# Altium schematic sheet 01 HK4530 V2.1 main.SchDoc
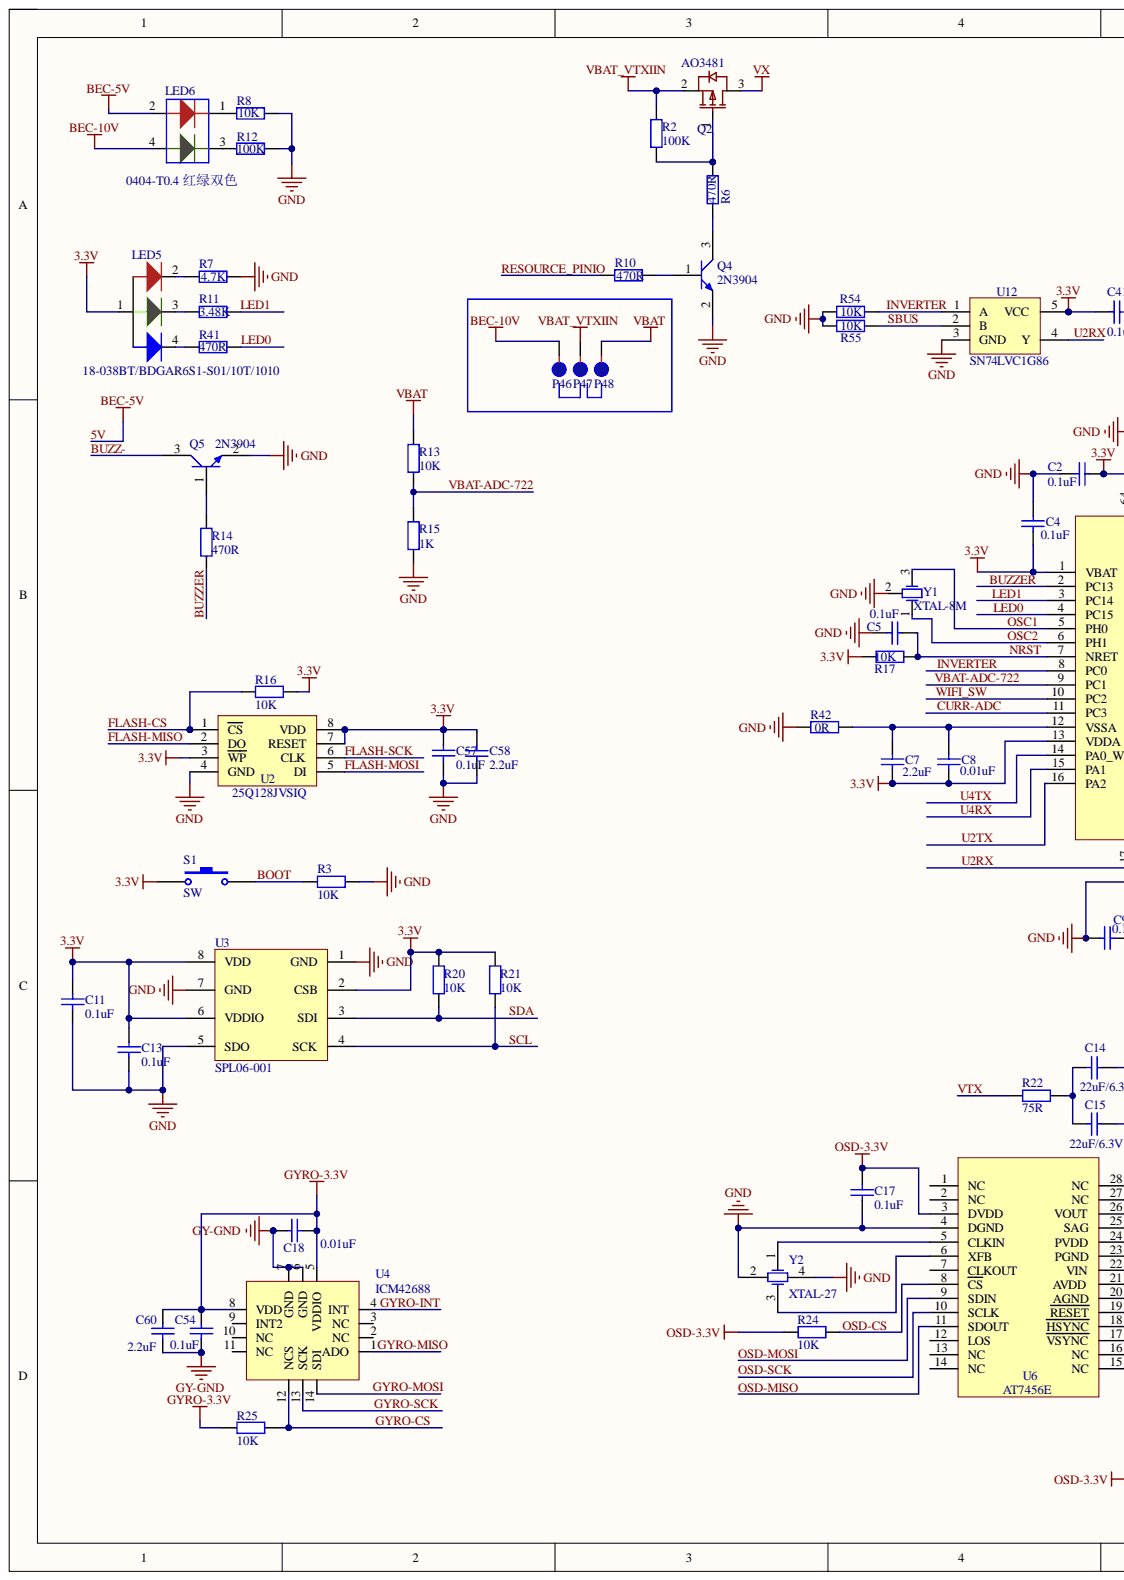
[diagram p0: 01 HK4530 V2.1 main.SchDoc, left]
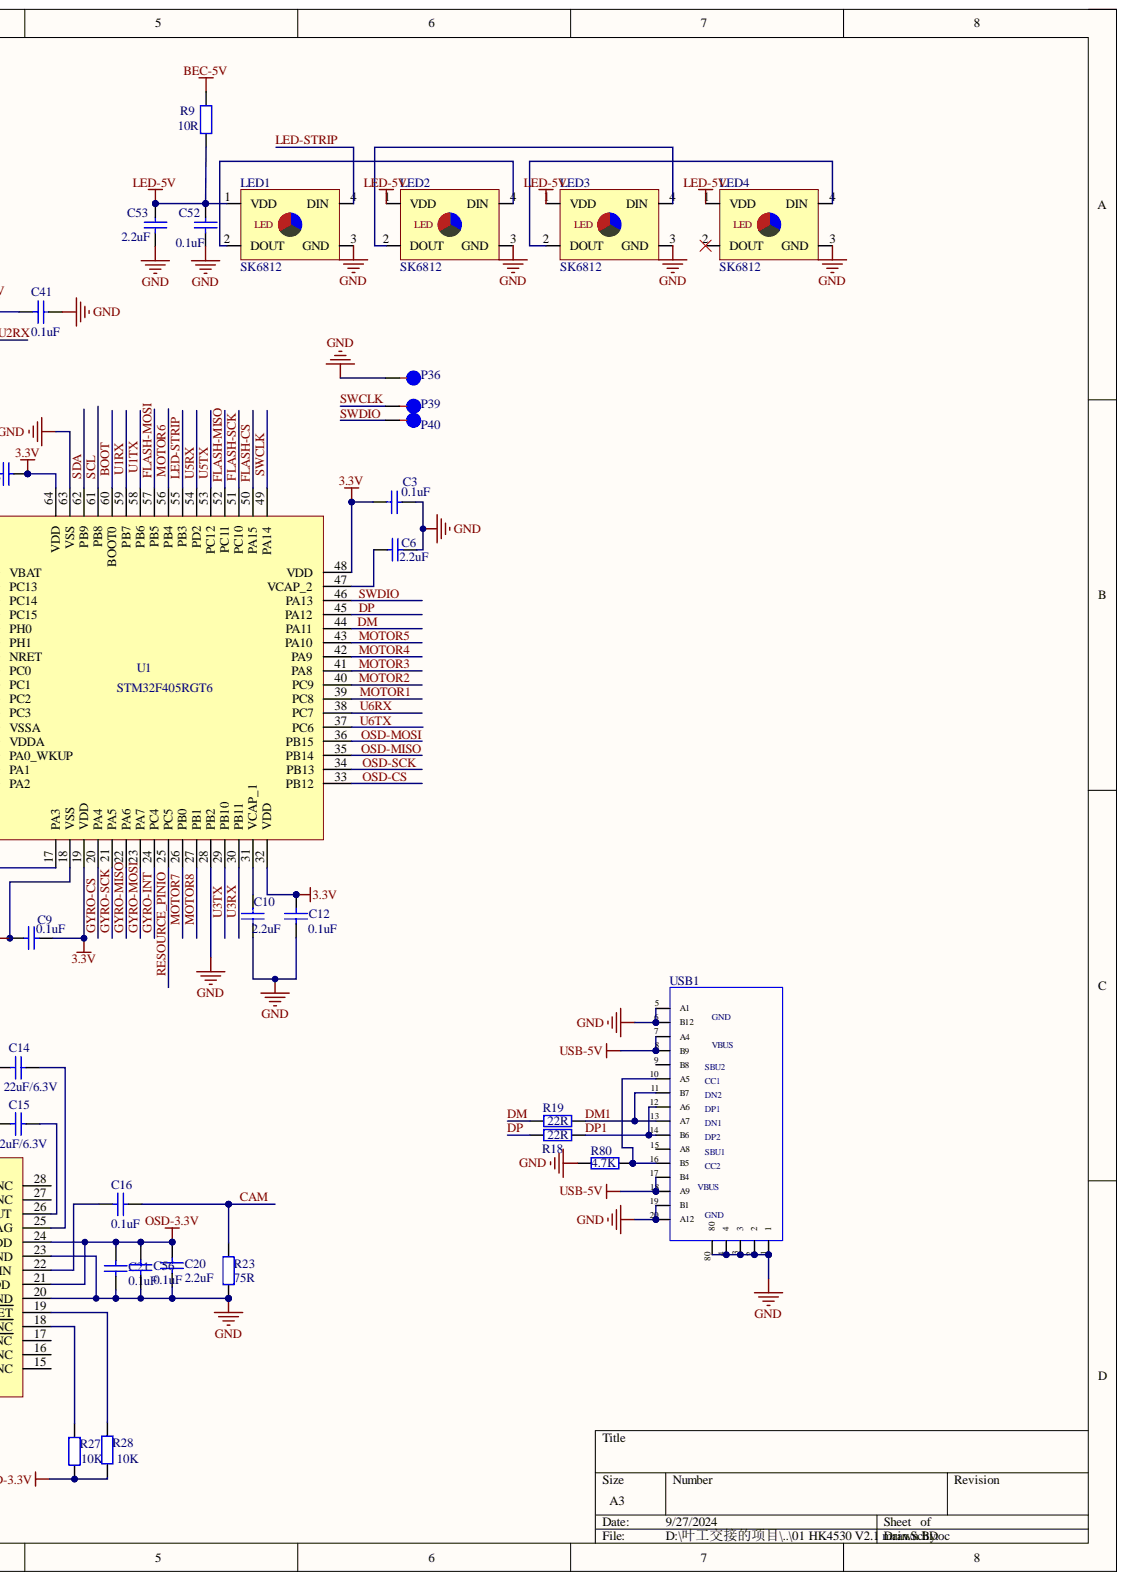
[diagram p1: 01 HK4530 V2.1 main.SchDoc, right]

## Parts
C2  0.1uF  [C0201]
C3  0.1uF  [C0201]
C4  0.1uF  [C0201]
C5  0.1uF  [C0201]
C6  2.2uF  [C0201]
C7  2.2uF  [C0201]
C8  0.01uF  [C0201]
C9  0.1uF  [C0201]
C10  2.2uF  [C0201]
C11  0.1uF  [C0201]
C12  0.1uF  [C0201]
C13  0.1uF  [C0201]
C14  22uF  value 22uF/6.3V  [C0603-D]
C15  22uF  value 22uF/6.3V  [C0603-D]
C16  0.1uF  [C0201]
C17  0.1uF  [C0201]
C18  0.01uF  [C0201]
C20  2.2uF  [C0201]
C21  0.1uF  [C0201]
C41  0.1uF  [C0201]
C52  0.1uF  [C0201]
C53  0.1uF  value 2.2uF  [C0201]
C54  0.1uF  [C0201]
C56  0.1uF  [C0201]
C57  0.1uF  [C0201]
C58  2.2uF  [C0201]
C60  2.2uF  [C0201]
LED1  SK6812  [SK6812-2020LED]
LED2  SK6812  [SK6812-2020LED]
LED3  SK6812  [SK6812-2020LED]
LED4  SK6812  [SK6812-2020LED]
LED5  18-038BT/BDGAR6S1-S01/10T/1010  [LED1010RGB]
LED6  0404-T0.4 红绿双色  [LED-RG1010]
P36  (no value)  [TP08]
P39  (no value)  [TP08]
P40  (no value)  [TP08]
P46  (no value)  [TP08]
P47  (no value)  [TP08]
P48  (no value)  [TP08]
Q2  AO3481  [SOT23-3]
Q4  2N3904  [3904-323]
Q5  2N3904  [3904-323]
R2  100K  [R0201]
R3  10K  [R0201]
R6  470R  [R0201]
R7  4.7K  [R0201]
R8  10K  [R0201]
R9  10R  [R0805]
R10  470R  [R0201]
R11  3.48K  [R0201]
R12  100K  [R0201]
R13  10K  [R0201]
R14  value 470R  [R0201]  (hidden comment: 100R)
R15  1K  [R0201]
R16  10K  [R0201]
R17  10K  [R0201]
R18  22R  [R0201]
R19  22R  [R0201]
R20  10K  [R0201]
R21  10K  [R0201]
R22  75R  [R0201]
R23  75R  [R0201]
R24  10K  [R0201]
R25  10K  [R0201]
R27  10K  [R0201]
R28  10K  [R0201]
R41  470R  [R0201]
R42  0R  [R0201]
R54  10K  [R0201]
R55  10K  [R0201]
R80  4.7K  [R0201]
S1  SW  [SWITCH]
U1  STM32F405RGT6  [STM32F405]
U2  25Q128JVSIQ  [25Q128JVSIQ-1]
U3  SPL06-001  [BMP280]
U4  ICM42688  [BMI270]
U6  AT7456E  [AT7456E]
U12  SN74LVC1G86  [SN74LVC1G86]
USB1  TYPEC-331  [TYPEC]  (value not drawn)
Y1  XTAL-8M  [XTAL3315]
Y2  XTAL-27  [XTAL-27M]

## Nets
3.3V: C2.1 C3.2 C4.1 C7.2 C8.2 C9.2 C11.1 C12.2 C13.1 C41.2 C57.1 C58.2 LED5.1 R16.1 R17.2 R20.2 R21.2 S1.2 U1.1(VBAT) U1.13(VDDA) U1.19(VDD) U1.32(VDD) U1.48(VDD) U1.64(VDD) U2.3(WP) U2.7(RESET) U2.8(VDD) U3.2(CSB) U3.6(VDDIO) U3.8(VDD) U12.5(VCC)
BEC-10V: LED6.4 P46.1
GND: C2.2 C3.1 C4.2 C5.2 C6.2 C9.1 C10.1 C11.2 C12.1 C13.2 C17.1 C20.1 C21.1 C41.1 C52.2 C53.2 C56.1 C57.2 C58.1 LED1.3(GND) LED2.3(GND) LED3.3(GND) LED4.3(GND) P36.1 Q4.2 Q5.2 R3.1 R7.2 R8.2 R12.2 R15.2 R23.2 R42.2 R54.1 R55.1 R80.2 U1.18(VSS) U1.28(PB2) U1.63(VSS) U2.4(GND) U3.1(GND) U3.5(SDO) U3.7(GND) U6.4(DGND) U6.20(AGND) U6.23(PGND) U12.3(GND) USB1.1 USB1.2 USB1.3 USB1.4 USB1.5(A1) USB1.6(B12) USB1.19(B1) USB1.20(A12) USB1.80 Y1.2 Y2.2 Y2.4
GY-GND: C18.1 C54.1 C60.1 U4.6(GND) U4.7(GND)
GYRO-3.3V: C18.2 C54.2 C60.2 R25.1 U4.5(VDDIO) U4.8(VDD)
LED-5V: C52.1 C53.1 LED1.1(VDD) LED2.1(VDD) LED3.1(VDD) LED4.1(VDD) R9.2
OSD-3.3V: C17.2 C20.2 C21.2 C56.2 R24.1 R27.1 R28.1 U6.3(DVDD) U6.21(AVDD) U6.24(PVDD)
USB-5V: USB1.7(A4) USB1.8(B9) USB1.17(B4) USB1.18(A9)
VBAT: P48.1 R13.1
VBAT_VTXIIN: P47.1 Q2.2 R2.1
VX: Q2.3
5V: LED6.2 R9.1
BOOT: R3.2 S1.1 U1.60(BOOT0)
BUZZ-: Q5.3
BUZZER: R14.1 U1.2(PC13)
CAM: C16.1 R23.1
CURR-ADC: U1.11(PC3)
DM: R19.1 U1.44(PA11)
DM1: R19.2 USB1.11(B7) USB1.13(A7)
DP: R18.1 U1.45(PA12)
DP1: R18.2 USB1.12(A6) USB1.14(B6)
FLASH-CS: R16.2 U1.50(PA15) U2.1(CS)
FLASH-MISO: U1.52(PC11) U2.2(DO)
FLASH-MOSI: U1.57(PB5) U2.5(DI)
FLASH-SCK: U1.51(PC10) U2.6(CLK)
GYRO-CS: R25.2 U1.20(PA4) U4.12(NCS)
GYRO-INT: U1.24(PC4) U4.4(INT)
GYRO-MISO: U1.22(PA6) U4.1(ADO)
GYRO-MOSI: U1.23(PA7) U4.14(SDI)
GYRO-SCK: U1.21(PA5) U4.13(SCK)
INVERTER: R54.2 U1.8(PC0) U12.1(A)
LED0: R41.2 U1.4(PC15)
LED1: R11.2 U1.3(PC14)
LED-STRIP: LED1.4(DIN) U1.55(PB3)
MOTOR1: U1.39(PC8)
MOTOR2: U1.40(PC9)
MOTOR3: U1.41(PA8)
MOTOR4: U1.42(PA9)
MOTOR5: U1.43(PA10)
MOTOR6: U1.56(PB4)
MOTOR7: U1.26(PB0)
MOTOR8: U1.27(PB1)
NRST: C5.1 R17.1 U1.7(NRET)
OSC1: U1.5(PH0) Y1.3
OSC2: U1.6(PH1) Y1.1
OSD-CS: R24.2 U1.33(PB12) U6.8(CS)
OSD-MISO: U1.35(PB14) U6.11(SDOUT)
OSD-MOSI: U1.36(PB15) U6.9(SDIN)
OSD-SCK: U1.34(PB13) U6.10(SCLK)
RESOURCE_PINIO: R10.2 U1.25(PC5)
SBUS: R55.2 U12.2(B)
SCL: R21.1 U1.61(PB8) U3.4(SCK)
SDA: R20.1 U1.62(PB9) U3.3(SDI)
SWCLK: P39.1 U1.49(PA14)
SWDIO: P40.1 U1.46(PA13)
U1RX: U1.59(PB7)
U1TX: U1.58(PB6)
U2RX: U1.17(PA3) U12.4(Y)
U2TX: U1.16(PA2)
U3RX: U1.30(PB11)
U3TX: U1.29(PB10)
U4RX: U1.15(PA1)
U4TX: U1.14(PA0_WKUP)
U5RX: U1.54(PD2)
U5TX: U1.53(PC12)
U6RX: U1.38(PC7)
U6TX: U1.37(PC6)
VBAT-ADC-722: R13.2 R15.1 U1.9(PC1)
VTX: R22.1
WIFI_SW: U1.10(PC2)
NetC6_1: C6.1 U1.47(VCAP_2)
NetC7_1: C7.1 C8.1 R42.1 U1.12(VSSA)
NetC10_2: C10.2 U1.31(VCAP_1)
NetC14_1: C14.1 U6.25(SAG)
NetC14_2: C14.2 C15.2 R22.2
NetC15_1: C15.1 U6.26(VOUT)
NetC16_2: C16.2 U6.22(VIN)
NetLED1_2: LED1.2(DOUT) LED2.4(DIN)
NetLED2_2: LED2.2(DOUT) LED3.4(DIN)
NetLED3_2: LED3.2(DOUT) LED4.4(DIN)
NetLED5_2: LED5.2 R7.1
NetLED5_3: LED5.3 R11.1
NetLED5_4: LED5.4 R41.1
NetLED6_1: LED6.1 R8.1
NetLED6_3: LED6.3 R12.1
NetQ2_1: Q2.1 R2.2 R6.1
NetQ4_1: Q4.1 R10.1
NetQ4_3: Q4.3 R6.2
NetQ5_1: Q5.1 R14.2
NetR27_2: R27.2 U6.18(HSYNC)
NetR28_2: R28.2 U6.19(RESET)
NetR80_1: R80.1 USB1.10(A5) USB1.16(B5)
NetU6_5: U6.5(CLKIN) Y2.1
NetU6_6: U6.6(XFB) Y2.3

Not connected: LED4 2(DOUT); U4 2(NC) 3(NC) 9(INT2) 10(NC) 11(NC); U6 1(NC) 2(NC) 7(CLKOUT) 12(LOS) 13(NC) 14(NC) 15(NC) 16(NC) 17(VSYNC) 27(NC) 28(NC); USB1 9(B8) 15(A8)

## Library data of the parts
C2-C7 C9-C13 C16-C18 C20 C21 C41 C52-C54 C56 C57 C60 = C0201: Capacitor; Component Kind: Standard
  C2-C5 C9 C12 C41: Library Name: Integrated_Library_gr.IntLib; Value: 0.1uF
  C6 C7 C10: Library Name: Integrated_Library_gr.IntLib; Value: 2.2uF
  C11 C13 C16 C17 C21 C52 C54 C56 C57: Library Name: Miscellaneous Connectors.IntLib; Value: 0.1uF
  C18: Library Name: Miscellaneous Connectors.IntLib; Value: 0.01uF
  C20 C60: Library Name: Miscellaneous Connectors.IntLib; Value: 2.2uF
  C53: Library Name: Miscellaneous Connectors.IntLib
C8 = C0201: Capacitor; Value: 0.1uF; Component Kind: Standard; Library Name: Integrated_Library_gr.IntLib
C14 C15 C58 = C0603: Capacitor; Component Kind: Standard; Library Name: Miscellaneous Connectors.IntLib
  C58: Value: 2.2uF
LED1-LED4 = SK6812-2020LED: Component Kind: Standard; Library Name: AT32F421K8U7temp V1.0.SCHLIB
LED5 = LED-RYX1010RGB: Component Kind: Standard; Library Name: Schlib-HK.SchLib
LED6 = LED-RG: Component Kind: Standard; Library Name: AT32F421K8U7temp V1.0.SCHLIB
P36 P39 P40 P46-P48 = TP: Component Kind: Standard
Q2 = AO3401: Component Kind: Standard; Library Name: AT32F421K8U7temp V1.0.SCHLIB
Q4 Q5 = 3904-23: NPN; Component Kind: Standard; Library Name: Miscellaneous Connectors.IntLib
R2 R3 R6 R9 R10 R13-R25 R27 R28 R42 R54 R55 = R0201: Resistor; Component Kind: Standard
  R2: Library Name: AT32F421K8U7temp V1.0.SCHLIB; Value: 100K
  R3 R16 R20 R21 R24 R25 R27 R28: Library Name: AT32F421K8U7temp V1.0.SCHLIB; Value: 10K
  R6 R10: Library Name: AT32F421K8U7temp V1.0.SCHLIB; Value: 470R
  R9 R13-R15: Library Name: AT32F421K8U7temp V1.0.SCHLIB
  R17 R54 R55: Library Name: Integrated_Library_gr.IntLib; Value: 10K
  R18 R19: Library Name: AT32F421K8U7temp V1.0.SCHLIB; Value: 22R
  R22 R23: Library Name: AT32F421K8U7temp V1.0.SCHLIB; Value: 75R
  R42: Library Name: AT32F421K8U7temp V1.0.SCHLIB; Value: 0R
R7 = R0201: Resistor; Value: 18K; Component Kind: Standard; Library Name: AT32F421K8U7temp V1.0.SCHLIB
R8 = R0201: Resistor; Value: 82K; Component Kind: Standard; Library Name: AT32F421K8U7temp V1.0.SCHLIB
R11 R41 = R0201: Resistor; Value: 24K; Component Kind: Standard; Library Name: AT32F421K8U7temp V1.0.SCHLIB
R12 = R0201: Resistor; Value: 470K; Component Kind: Standard; Library Name: AT32F421K8U7temp V1.0.SCHLIB
R80 = R0201: Resistor; Value: 22R; Component Kind: Standard; Library Name: AT32F421K8U7temp V1.0.SCHLIB
S1 = SW: SWITCH; Component Kind: Standard; Library Name: Miscellaneous Connectors.IntLib
U1 = STM32F405: IC; Component Kind: Standard; Library Name: Integrated_Library_gr.IntLib
U2 = MU9943: IC; Component Kind: Standard; Library Name: Miscellaneous Connectors.IntLib
U3 = BMP280: Component Kind: Standard; Library Name: AT32F421K8U7temp V1.0.SCHLIB
U4 = BMI270: Component Kind: Standard; Library Name: AT32F421K8U7temp V1.0.SCHLIB
U6 = AT7456E: IC; Component Kind: Standard; Library Name: Miscellaneous Connectors.IntLib
Y1 = XTAL-8M: OSC; Component Kind: Standard; Library Name: F722 BL-32 V2.0.SCHLIB
Y2 = XTAL-27: OSC; Component Kind: Standard; Library Name: Miscellaneous Connectors.IntLib
U12 = SN74LVC1G86: Component Kind: Standard; Library Name: Integrated_Library_gr.IntLib
USB1 = TYPEC-331: Component Kind: Standard; Library Name: MK4 F722 ELRS.SCHLIB
